# Altium schematic sheet MCU.SchDoc(MCU)
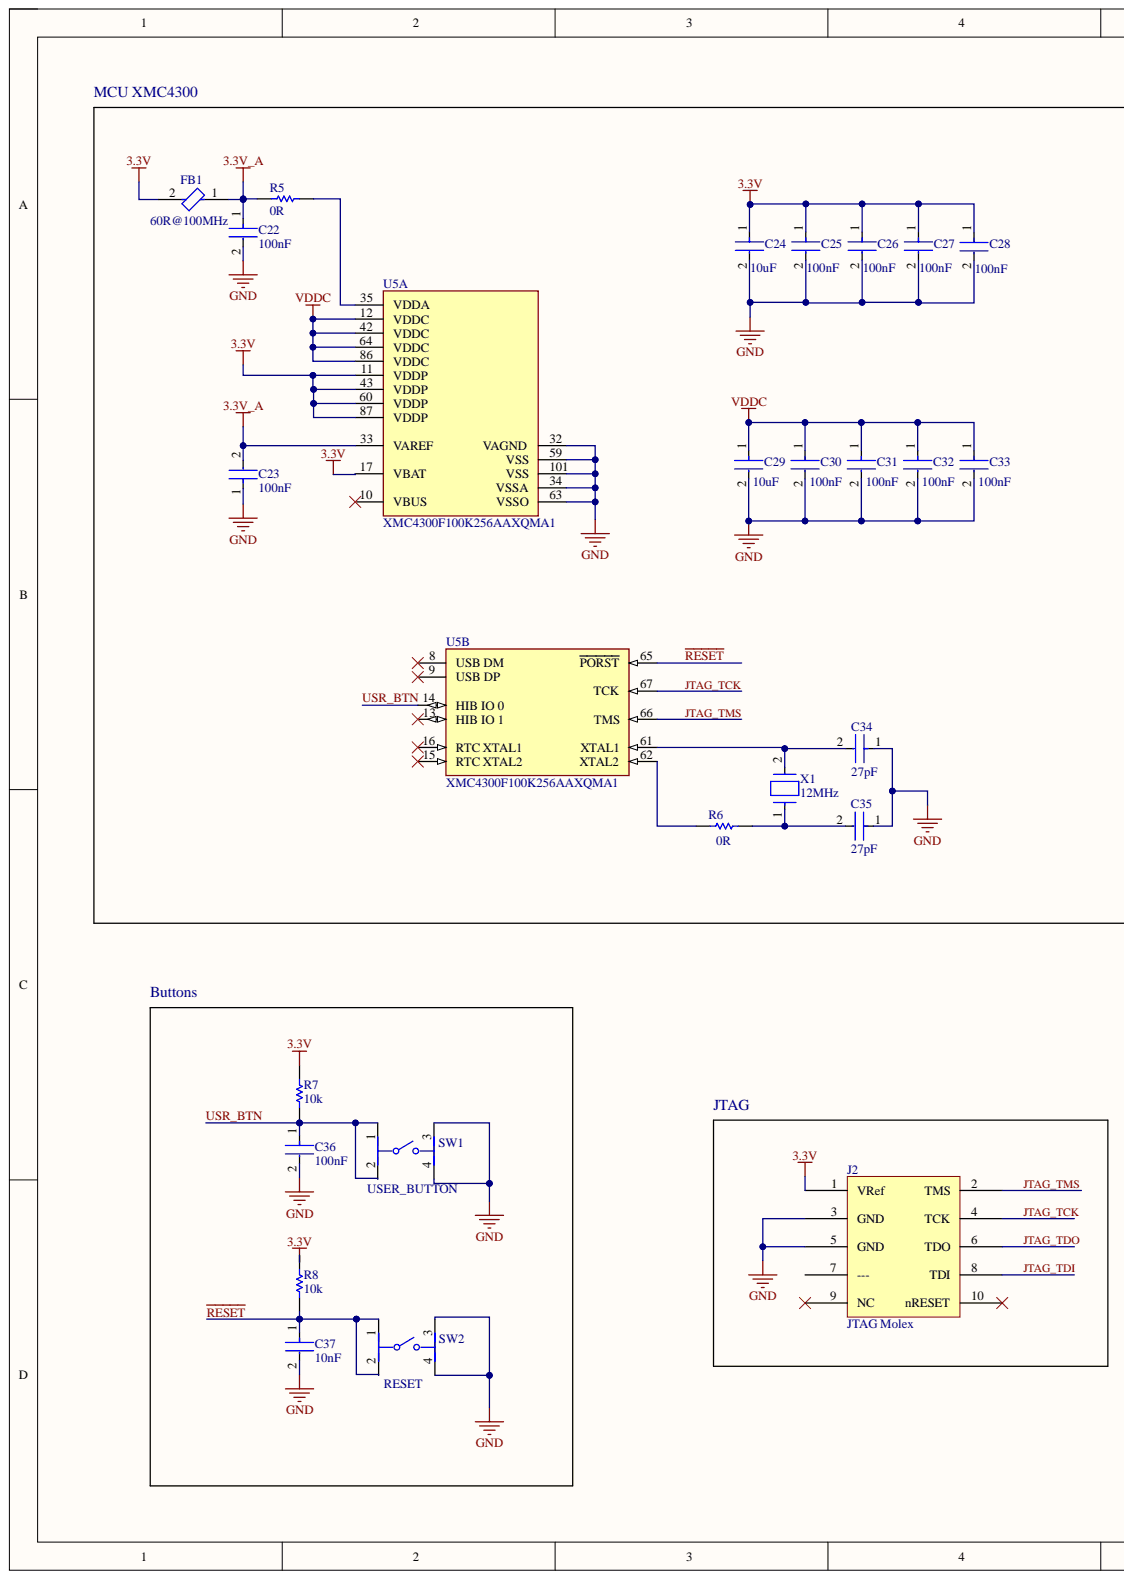
[diagram p0: MCU.SchDoc, left]
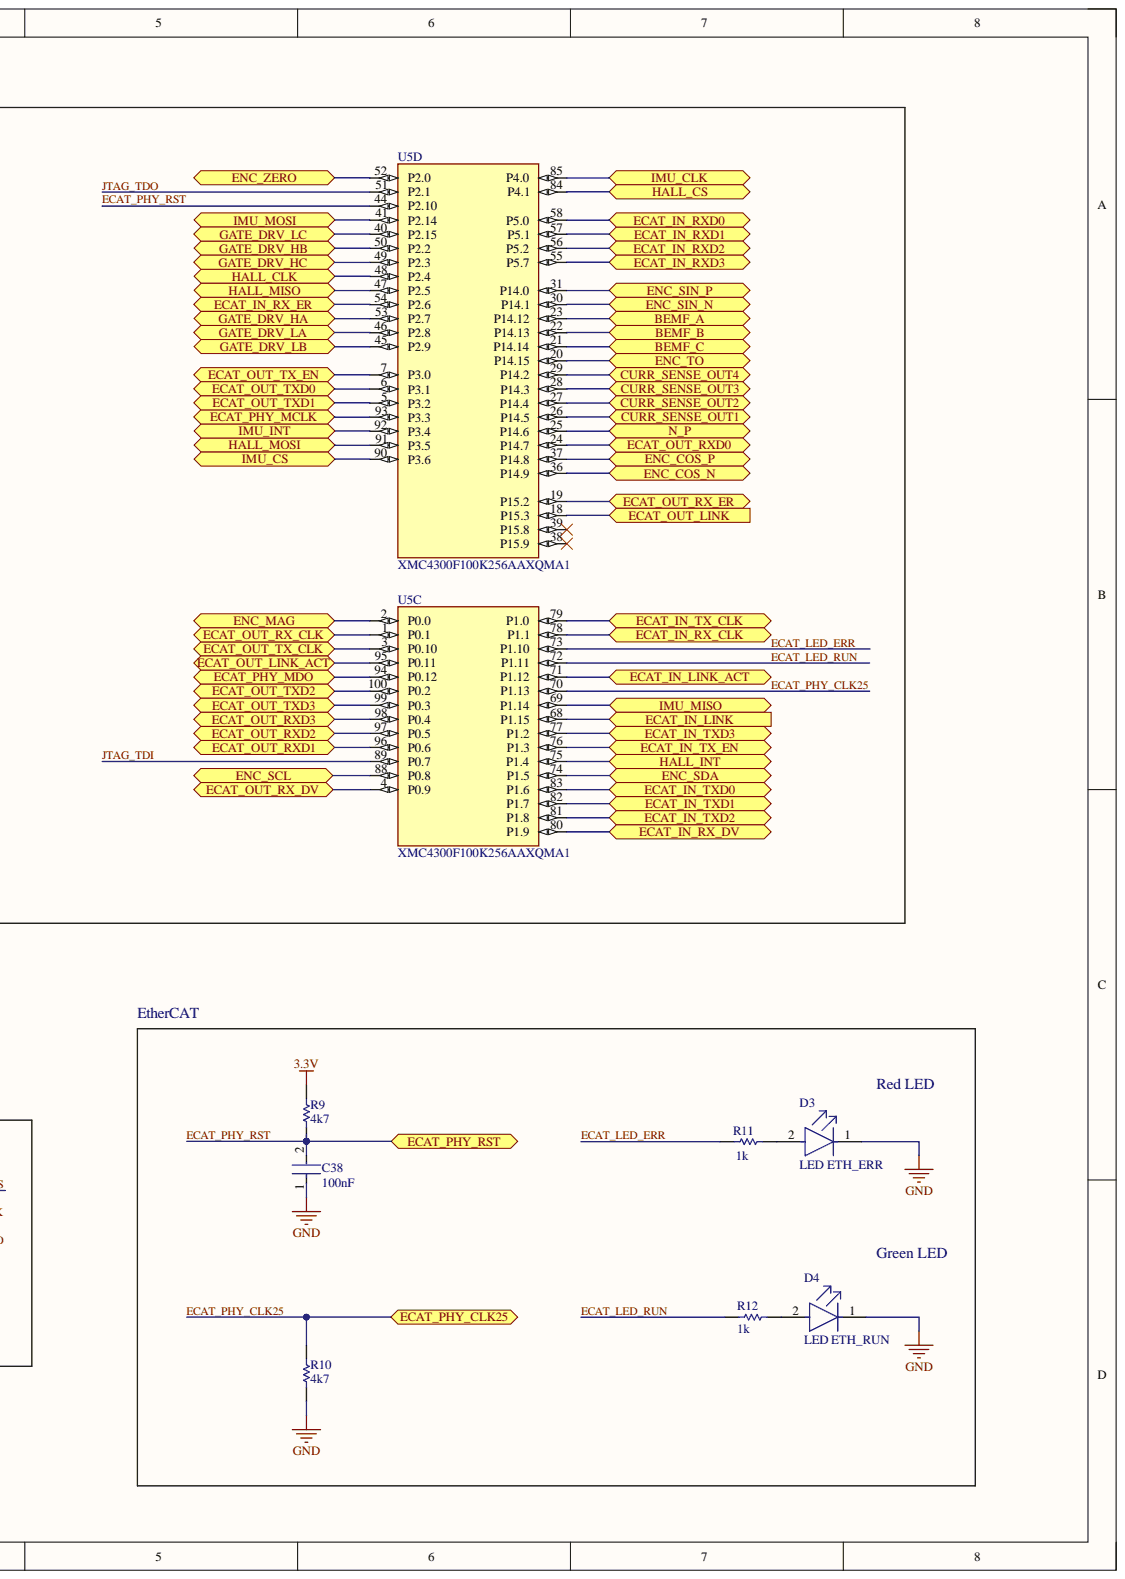
[diagram p1: MCU.SchDoc, right]

## Parts
C22  100nF  [FP-C0805C-DN-MFG]
C23  100nF  [FP-C0805C-DN-MFG]
C24  10uF  [FP-C0805C-DN-MFG]
C25  100nF  [FP-C0805C-DN-MFG]
C26  100nF  [FP-C0805C-DN-MFG]
C27  100nF  [FP-C0805C-DN-MFG]
C28  100nF  [FP-C0805C-DN-MFG]
C29  10uF  [FP-C0805C-DN-MFG]
C30  100nF  [FP-C0805C-DN-MFG]
C31  100nF  [FP-C0805C-DN-MFG]
C32  100nF  [FP-C0805C-DN-MFG]
C33  100nF  [FP-C0805C-DN-MFG]
C34  27pF  [FP-C0805C-DN-MFG]
C35  27pF  [FP-C0805C-DN-MFG]
C36  100nF  [FP-C0805C-DN-MFG]
C37  10nF  [FP-C0805C-DN-MFG]
C38  100nF  [FP-C0805C-DN-MFG]
D3  LED ETH_ERR  [FP-LTST-C191GKT-MFG]
D4  LED ETH_RUN  Color: Green  [FP-LTST-C191GKT-MFG]
FB1  60R@100MHz  [FP-BLM18-0_15-t0_8-IPC_C]
J2  JTAG Molex  [2X5_JTAG_HEADER]
R5  0R  [RESC2013X50X30LL20T20]
R6  0R  [RESC2013X50X30LL20T20]
R7  10k  [RESC2013X50X30LL20T20]
R8  10k  [RESC2013X50X30LL20T20]
R9  4k7  [RESC2013X50X30LL20T20]
R10  4k7  [RESC2013X50X30LL20T20]
R11  1k  [RESC2013X50X30LL20T20]
R12  1k  [RESC2013X50X30LL20T20]
SW1  USER_BUTTON  [FP-TL3305AF260QG-MFG]
SW2  RESET  [FP-TL3305AF260QG-MFG]
U5  XMC4300F100K256AAXQMA1  [FP-PG-LQFP-100-MFG]  (parts A,B,C,D)
X1  12MHz  [FP-ABM3-MFG]

## Ports
BEMF_A, BEMF_B, BEMF_C, CURR_SENSE_OUT1, CURR_SENSE_OUT2, CURR_SENSE_OUT3, CURR_SENSE_OUT4, ECAT_IN_LINK, ECAT_IN_LINK_ACT, ECAT_IN_RX_CLK, ECAT_IN_RX_DV, ECAT_IN_RX_ER, ECAT_IN_RXD0, ECAT_IN_RXD1, ECAT_IN_RXD2, ECAT_IN_RXD3, ECAT_IN_TX_CLK, ECAT_IN_TX_EN, ECAT_IN_TXD0, ECAT_IN_TXD1, ECAT_IN_TXD2, ECAT_IN_TXD3, ECAT_OUT_LINK, ECAT_OUT_LINK_ACT, ECAT_OUT_RX_CLK, ECAT_OUT_RX_DV, ECAT_OUT_RX_ER, ECAT_OUT_RXD0, ECAT_OUT_RXD1, ECAT_OUT_RXD2, ECAT_OUT_RXD3, ECAT_OUT_TX_CLK, ECAT_OUT_TX_EN, ECAT_OUT_TXD0, ECAT_OUT_TXD1, ECAT_OUT_TXD2, ECAT_OUT_TXD3, ECAT_PHY_CLK25, ECAT_PHY_MCLK, ECAT_PHY_MDO, ECAT_PHY_RST, ENC_COS_N, ENC_COS_P, ENC_MAG, ENC_SCL, ENC_SDA, ENC_SIN_N, ENC_SIN_P, ENC_TO, ENC_ZERO, GATE_DRV_HA, GATE_DRV_HB, GATE_DRV_HC, GATE_DRV_LA, GATE_DRV_LB, GATE_DRV_LC, HALL_CLK, HALL_CS, HALL_INT, HALL_MISO, HALL_MOSI, IMU_CLK, IMU_CS, IMU_INT, IMU_MISO, IMU_MOSI, N_P

## Nets
3.3V: C24.1 C25.1 C26.1 C27.1 C28.1 FB1.2 J2.1(VRef) R7.1 R8.1 R9.2 U5.11(VDDP) U5.17(VBAT) U5.43(VDDP) U5.60(VDDP) U5.87(VDDP)
3.3V_A: C22.1 C23.2 FB1.1 R5.1 U5.33(VAREF)
GND: C22.2 C23.1 C24.2 C25.2 C26.2 C27.2 C28.2 C29.2 C30.2 C31.2 C32.2 C33.2 C34.1 C35.1 C36.2 C37.2 C38.1 D3.1 D4.1 J2.3(GND) J2.5(GND) R10.1 SW1.3 SW1.4 SW2.3 SW2.4 U5.32(VAGND) U5.34(VSSA) U5.59(VSS) U5.63(VSSO) U5.101(VSS)
VDDC: C29.1 C30.1 C31.1 C32.1 C33.1 U5.12(VDDC) U5.42(VDDC) U5.64(VDDC) U5.86(VDDC)
BEMF_A: U5.23(P14.12) ; port BEMF_A
BEMF_B: U5.22(P14.13) ; port BEMF_B
BEMF_C: U5.21(P14.14) ; port BEMF_C
CURR_SENSE_OUT1: U5.26(P14.5) ; port CURR_SENSE_OUT1
CURR_SENSE_OUT2: U5.27(P14.4) ; port CURR_SENSE_OUT2
CURR_SENSE_OUT3: U5.28(P14.3) ; port CURR_SENSE_OUT3
CURR_SENSE_OUT4: U5.29(P14.2) ; port CURR_SENSE_OUT4
ECAT_IN_LINK: U5.68(P1.15) ; port ECAT_IN_LINK
ECAT_IN_LINK_ACT: U5.71(P1.12) ; port ECAT_IN_LINK_ACT
ECAT_IN_RX_CLK: U5.78(P1.1) ; port ECAT_IN_RX_CLK
ECAT_IN_RX_DV: U5.80(P1.9) ; port ECAT_IN_RX_DV
ECAT_IN_RX_ER: U5.54(P2.6) ; port ECAT_IN_RX_ER
ECAT_IN_RXD0: U5.58(P5.0) ; port ECAT_IN_RXD0
ECAT_IN_RXD1: U5.57(P5.1) ; port ECAT_IN_RXD1
ECAT_IN_RXD2: U5.56(P5.2) ; port ECAT_IN_RXD2
ECAT_IN_RXD3: U5.55(P5.7) ; port ECAT_IN_RXD3
ECAT_IN_TX_CLK: U5.79(P1.0) ; port ECAT_IN_TX_CLK
ECAT_IN_TX_EN: U5.76(P1.3) ; port ECAT_IN_TX_EN
ECAT_IN_TXD0: U5.83(P1.6) ; port ECAT_IN_TXD0
ECAT_IN_TXD1: U5.82(P1.7) ; port ECAT_IN_TXD1
ECAT_IN_TXD2: U5.81(P1.8) ; port ECAT_IN_TXD2
ECAT_IN_TXD3: U5.77(P1.2) ; port ECAT_IN_TXD3
ECAT_LED_ERR: R11.1 U5.73(P1.10)
ECAT_LED_RUN: R12.1 U5.72(P1.11)
ECAT_OUT_LINK: U5.18(P15.3) ; port ECAT_OUT_LINK
ECAT_OUT_LINK_ACT: U5.95(P0.11) ; port ECAT_OUT_LINK_ACT
ECAT_OUT_RX_CLK: U5.1(P0.1) ; port ECAT_OUT_RX_CLK
ECAT_OUT_RX_DV: U5.4(P0.9) ; port ECAT_OUT_RX_DV
ECAT_OUT_RX_ER: U5.19(P15.2) ; port ECAT_OUT_RX_ER
ECAT_OUT_RXD0: U5.24(P14.7) ; port ECAT_OUT_RXD0
ECAT_OUT_RXD1: U5.96(P0.6) ; port ECAT_OUT_RXD1
ECAT_OUT_RXD2: U5.97(P0.5) ; port ECAT_OUT_RXD2
ECAT_OUT_RXD3: U5.98(P0.4) ; port ECAT_OUT_RXD3
ECAT_OUT_TX_CLK: U5.3(P0.10) ; port ECAT_OUT_TX_CLK
ECAT_OUT_TX_EN: U5.7(P3.0) ; port ECAT_OUT_TX_EN
ECAT_OUT_TXD0: U5.6(P3.1) ; port ECAT_OUT_TXD0
ECAT_OUT_TXD1: U5.5(P3.2) ; port ECAT_OUT_TXD1
ECAT_OUT_TXD2: U5.100(P0.2) ; port ECAT_OUT_TXD2
ECAT_OUT_TXD3: U5.99(P0.3) ; port ECAT_OUT_TXD3
ECAT_PHY_CLK25: R10.2 U5.70(P1.13) ; port ECAT_PHY_CLK25
ECAT_PHY_MCLK: U5.93(P3.3) ; port ECAT_PHY_MCLK
ECAT_PHY_MDO: U5.94(P0.12) ; port ECAT_PHY_MDO
ECAT_PHY_RST: C38.2 R9.1 U5.44(P2.10) ; port ECAT_PHY_RST
ENC_COS_N: U5.36(P14.9) ; port ENC_COS_N
ENC_COS_P: U5.37(P14.8) ; port ENC_COS_P
ENC_MAG: U5.2(P0.0) ; port ENC_MAG
ENC_SCL: U5.88(P0.8) ; port ENC_SCL
ENC_SDA: U5.74(P1.5) ; port ENC_SDA
ENC_SIN_N: U5.30(P14.1) ; port ENC_SIN_N
ENC_SIN_P: U5.31(P14.0) ; port ENC_SIN_P
ENC_TO: U5.20(P14.15) ; port ENC_TO
ENC_ZERO: U5.52(P2.0) ; port ENC_ZERO
GATE_DRV_HA: U5.53(P2.7) ; port GATE_DRV_HA
GATE_DRV_HB: U5.50(P2.2) ; port GATE_DRV_HB
GATE_DRV_HC: U5.49(P2.3) ; port GATE_DRV_HC
GATE_DRV_LA: U5.46(P2.8) ; port GATE_DRV_LA
GATE_DRV_LB: U5.45(P2.9) ; port GATE_DRV_LB
GATE_DRV_LC: U5.40(P2.15) ; port GATE_DRV_LC
HALL_CLK: U5.48(P2.4) ; port HALL_CLK
HALL_CS: U5.84(P4.1) ; port HALL_CS
HALL_INT: U5.75(P1.4) ; port HALL_INT
HALL_MISO: U5.47(P2.5) ; port HALL_MISO
HALL_MOSI: U5.91(P3.5) ; port HALL_MOSI
IMU_CLK: U5.85(P4.0) ; port IMU_CLK
IMU_CS: U5.90(P3.6) ; port IMU_CS
IMU_INT: U5.92(P3.4) ; port IMU_INT
IMU_MISO: U5.69(P1.14) ; port IMU_MISO
IMU_MOSI: U5.41(P2.14) ; port IMU_MOSI
JTAG_TCK: J2.4(TCK) U5.67(TCK)
JTAG_TDI: J2.8(TDI) U5.89(P0.7)
JTAG_TDO: J2.6(TDO) U5.51(P2.1)
JTAG_TMS: J2.2(TMS) U5.66(TMS)
N_P: U5.25(P14.6) ; port N_P
~{RESET}: C37.1 R8.2 SW2.1 SW2.2 U5.65(PORST)
USR_BTN: C36.1 R7.2 SW1.1 SW1.2 U5.14(HIB_IO_0)
NetC34_2: C34.2 U5.61(XTAL1) X1.2
NetC35_2: C35.2 R6.2 X1.1
NetD3_2: D3.2 R11.2
NetD4_2: D4.2 R12.2
NetR5_2: R5.2 U5.35(VDDA)
NetR6_1: R6.1 U5.62(XTAL2)

Not connected: J2 7(---) 9(NC) 10(nRESET); U5 8(USB_DM) 9(USB_DP) 10(VBUS) 13(HIB_IO_1) 15(RTC_XTAL2) 16(RTC_XTAL1) 38(P15.9) 39(P15.8)

## Library data of the parts
C22-C38 = CMP-2007-00279-2: CAP CER 0.1UF 50V X7R 0805; Manufacturer: KEMET; Manufacturer Part Number: C0805C104K5RACTU; Capacitance: 0.10 μF; Material: Ceramic; Temperature Coefficient: 30ppm/°C; Tolerance: 0.1; Value: 0.10 μF; Automotive: No; Category: Cap; Component Kind: Standard; ComponentLink2Description: Datasheet; ComponentLink2URL: https://content.kemet.com/datasheets/KEM_C1003_C0G_SMD.pdf; Device Class L1: Passive Components; Device Class L2: Capacitors; Device Class L3: Ceramic Capacitors; Digikey Description: CAP CER 0.1UF 50V X7R 0805; Height: 0.88mm; Ipc Land Pattern Name: CAPC200125X78; Lead Free: Yes; Library Name: Altium Content Vault; Mouser Description: Cap Ceramic 0.1uF 50V X7R 10% SMD 2.0 x 1.2 mm 125 C Paper T/R; Octopart: https://octopart.com/c0805c104k5ractu-kemet-143926; Package: C0805C-DN; RoHS: TRUE; Temperature: -55°C to +125°C; Temperature Characteristic: X7R; Temperature Range High: +125°C; Temperature Range Low: -55°C; Voltage Rating: 50 V
D3 = CMP-22017-000029-1: KPHD-1608LVCGCK; Color: Green; Dominant Wavelength: 569nm; Forward Current: 30mA; Forward Voltage: 2.1V; Lens Color: Colorless; Lens Style: Clear; Luminous Intensity: 12mcd; Number of Elements: 1; Power Dissipation: 100mW; Reverse Voltage: 5V; Test Current: 20mA; Viewing Angle: 130°; Wavelength: 565nm; Case Code (Imperial): 0603; Case Code (Metric): 1608; Case/Package: 0603; Component Kind: Standard; Depth: 0.8mm; Height: 0.55mm; Illumination Color: Green; Lead Free: Lead Free; Length: 1.6mm; Lens Transparency: Clear; Library Name: Altium Content Vault; Max Operating Temperature: 85°C; Min Operating Temperature: -55°C; Mount: Surface Mount; Number of LEDs: 1; Number of Pins: 2; Package Quantity: 1; Packaging: Tape and Reel; Power Rating: 100mW; Radiation Hardening: No; Width: 0.8mm
D4 = CMP-22017-000029-1: KPHD-1608LVCGCK; Dominant Wavelength: 569nm; Forward Current: 30mA; Forward Voltage: 2.1V; Lens Color: Colorless; Lens Style: Clear; Luminous Intensity: 12mcd; Number of Elements: 1; Power Dissipation: 100mW; Reverse Voltage: 5V; Test Current: 20mA; Viewing Angle: 130°; Wavelength: 565nm; Case Code (Imperial): 0603; Case Code (Metric): 1608; Case/Package: 0603; Component Kind: Standard; Depth: 0.8mm; Height: 0.55mm; Illumination Color: Green; Lead Free: Lead Free; Length: 1.6mm; Lens Transparency: Clear; Library Name: Altium Content Vault; Max Operating Temperature: 85°C; Min Operating Temperature: -55°C; Mount: Surface Mount; Number of LEDs: 1; Number of Pins: 2; Package Quantity: 1; Packaging: Tape and Reel; Power Rating: 100mW; Radiation Hardening: No; Width: 0.8mm
FB1 = CMP-06046-002563-1: Chip Ferrite Bead, 0603, 60Ω @ 100MHz, 0.1Ω, , 1A    BLM18PG600SH1D; Current Rating: 500mA; DC Resistance (DCR): 100mR; Impedance: 60R; Material: Steel; Number of Circuits: 1; Shielding: Unshielded; Tolerance: 25%; Case Code (Imperial): 0603; Case Code (Metric): 1608; Case/Package: 0603; Component Kind: Standard; Contact Plating: Tin; Height: 0.8mm; Length: 1.6mm; Library Name: Altium Content Vault; Max Current Rating: 500mA; Max DC Current: 500mA; Max Operating Temperature: 125°C; Min Operating Temperature: -55°C; Mount: Surface Mount; Number of Lines: 1; Package Quantity: 4000; Packaging: Tape and Reel; Ratings: AEC-Q200; REACH SVHC: No SVHC; RoHS Compliant: Yes; Series: EMIFIL®, BLM18P; Termination: SMD/SMT; Test Frequency: 100MHz; Width: 0.8mm
J2 = 5x2_1,27mm_JTAG: MOLEX for JTAG, 5x2, 1,27 mm; Component Kind: Standard; Library Name: CONNECTOR.SchLib
R5-R8 = CMP-2001-04896-1: Manufacturer: Vishay Dale; Part Number: CRCW08050000Z0EA; Component Kind: Standard; Library Name: Altium Content Vault
R9-R12 = CMP-2001-04896-1: 0805; Manufacturer: Vishay Dale; Part Number: CRCW08050000Z0EA; Component Kind: Standard; Library Name: Altium Content Vault
SW1 SW2 = CMP-17879-000010-1: SWITCH TACTILE SPST-NO 50MA 12V    TL3305AF260QG; Actuator Type: Standard; Illumination Type: Non-Illuminated; Mechanical Life: 200000Cycles; Operating Force: 260gf; Switch Function: Off-Mom; Switch Travel: 0.2mm; Throw Configuration: SPST; Component Kind: Standard; Lead Free: Lead Free; Library Name: Altium Content Vault; Max Operating Temperature: 70°C; Min Operating Temperature: -20°C; Mount: Surface Mount; Packaging: Tape and Reel; Termination: Gull Wing
U5 = CMP-32808-000041-1: IC MCU 32BIT 256KB FLASH 100LQFP; Component Kind: Standard; Library Name: Altium Content Vault; RoHS Compliant: Yes
X1 = CMP-27762-007917-1: Crystal 12MHz SMD-2 5mm x 3.2mm; ESR (Equivalent Series Resistance): 60R; Frequency Stability: 30ppm; Frequency Tolerance: 20ppm; Load Capacitance: 18pF; Case/Package: CSMD; Component Kind: Standard; Depth: 3.2mm; Frequency: 12MHz; Height: 0.051inch; Lead Free: Lead Free; Length: 5mm; Library Name: Altium Content Vault; Max Operating Temperature: 85°C; Min Operating Temperature: -40°C; Mount: Surface Mount; Number of Pins: 2; Operating Frequency: 12 MHz; Package Quantity: 1000; Packaging: Tape and Reel; Radiation Hardening: No; Resistor Type: Ceramic; Series: ABM3; Series Resistance: 60R; Termination: SMD/SMT; Tolerance: 20ppm; Width: 0.126inch
